# Altium schematic sheet TE0887.SchDoc(TE0887)
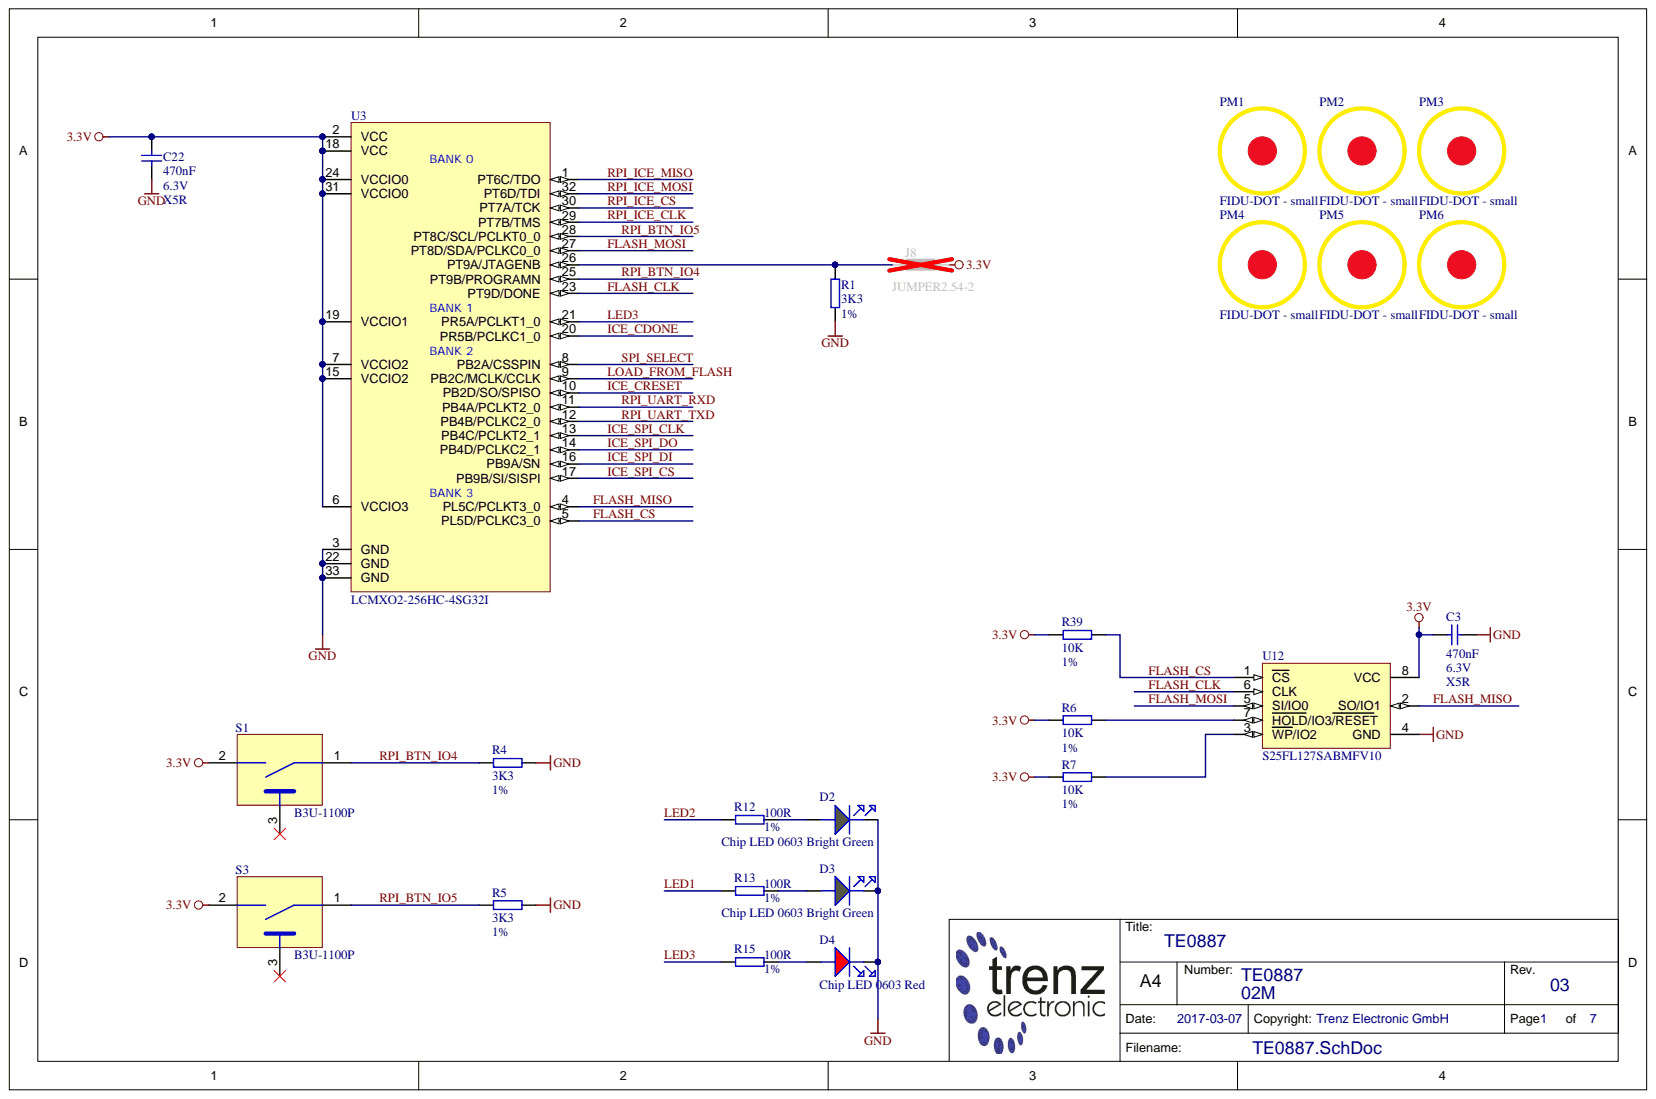
[diagram p0: TE0887.SchDoc]

## Parts
C3  470nF  Voltage: 6.3V  [CAPC0603X33N]
C22  470nF  Technology: X5R  Voltage: 6.3V  [CAPC0603X33N]
D2  Chip LED 0603 Bright Green  Case: 0603  [chipLED_0603_Green]
D3  Chip LED 0603 Bright Green  Case: 0603  [chipLED_0603_Green]
D4  Chip LED 0603 Red  Case: 0603  Database Table Name: LED  [chipLED_0603_Red]
J8  JUMPER2.54-2  [JUMPER2_54-2]
PM1  FIDU-DOT - small  [FIDU-DOT - small]
PM2  FIDU-DOT - small  [FIDU-DOT - small]
PM3  FIDU-DOT - small  [FIDU-DOT - small]
PM4  FIDU-DOT - small  [FIDU-DOT - small]
PM5  FIDU-DOT - small  [FIDU-DOT - small]
PM6  FIDU-DOT - small  [FIDU-DOT - small]
R1  3K3  Tolerance: 1%  [RESC1005X40N]
R4  3K3  Tolerance: 1%  [RESC1005X40N]
R5  3K3  Tolerance: 1%  [RESC1005X40N]
R6  10K  [RESC1005X40N]
R7  10K  Tolerance: 1%  [RESC1005X40N]
R12  100R  Tolerance: 1%  [RESC1005X40N]
R13  100R  Tolerance: 1%  [RESC1005X40N]
R15  100R  Tolerance: 1%  [RESC1005X40N]
R39  10K  Tolerance: 1%  [RESC1005X40N]
S1  B3U-1100P  [B3U-1100P]
S3  B3U-1100P  [B3U-1100P]
U3  LCMXO2-256HC-4SG32I  [PQFN50P500X500X60-33N]
U12  S25FL127SABMFV10  [SOIC127P790X216-8N]

## Nets
3.3V: C3.1 C22.1 J8.2 R6.1 R7.1 R39.1 S1.2 S3.2 U3.2(VCC) U3.6(VCCIO3) U3.7(VCCIO2) U3.15(VCCIO2) U3.18(VCC) U3.19(VCCIO1) U3.24(VCCIO0) U3.31(VCCIO0) U12.8(VCC)
GND: C3.2 C22.2 D2.K D3.K D4.K R1.1 R4.1 R5.1 U3.3(GND) U3.22(GND) U3.33(GND) U12.4(GND)
FLASH_CLK: U3.23(PT9D/DONE) U12.6(CLK)
FLASH_CS: R39.2 U3.5(PL5D/PCLKC3_0) U12.1(CS)
FLASH_MISO: U3.4(PL5C/PCLKT3_0) U12.2(SO/IO1)
FLASH_MOSI: U3.27(PT8D/SDA/PCLKC0_0) U12.5(SI/IO0)
ICE_CDONE: U3.20(PR5B/PCLKC1_0)
ICE_CRESET: U3.10(PB2D/SO/SPISO)
ICE_SPI_CLK: U3.13(PB4C/PCLKT2_1)
ICE_SPI_CS: U3.17(PB9B/SI/SISPI)
ICE_SPI_DI: U3.16(PB9A/SN)
ICE_SPI_DO: U3.14(PB4D/PCLKC2_1)
LED1: R13.1
LED2: R12.1
LED3: R15.1 U3.21(PR5A/PCLKT1_0)
LOAD_FROM_FLASH: U3.9(PB2C/MCLK/CCLK)
RPI_BTN_IO4: R4.2 S1.1 U3.25(PT9B/PROGRAMN)
RPI_BTN_IO5: R5.2 S3.1 U3.28(PT8C/SCL/PCLKT0_0)
RPI_ICE_CLK: U3.29(PT7B/TMS)
RPI_ICE_CS: U3.30(PT7A/TCK)
RPI_ICE_MISO: U3.1(PT6C/TDO)
RPI_ICE_MOSI: U3.32(PT6D/TDI)
RPI_UART_RXD: U3.11(PB4A/PCLKT2_0)
RPI_UART_TXD: U3.12(PB4B/PCLKC2_0)
SPI_SELECT: U3.8(PB2A/CSSPIN)
NetD2_A: D2.A R12.2
NetD3_A: D3.A R13.2
NetD4_A: D4.A R15.2
NetJ8_1: J8.1 R1.2 U3.26(PT9A/JTAGENB)
NetR6_2: R6.2 U12.7(HOLD/IO3/RESET)
NetR7_2: R7.2 U12.3(WP/IO2)

Not connected: S1 3; S3 3

## Library data of the parts
C3 C22 = 24921: KON 470nF X5R 6.3V SMD 20%; Database Table Name: C_0603_0201; Mixed: SMT; Preferred: Yes; Value: 470n; AN: 24921; Case: 0603 (0201); Component Kind: Standard; Library Name: TE.DbLib; Tolerance: 20%
  C3: Technology: X5R
D2 D3 = 25986: H-ART: 150 060 VS7 500 0; Mixed: SMT; Preferred: Yes; Voltage: 2.0; AN: 25986; Component Kind: Standard; Database Table Name: LED; Description: Chip LED 0603 Bright Green; Library Name: TE.DbLib; HelpURL: B:\AD\adlib\datasheet\WL_SMCW
D4 = 25985: H-ART: 150 060 RS7 500 0; Mixed: SMT; Preferred: Yes; Voltage: 2.0; AN: 25985; Component Kind: Standard; Description: Chip LED 0603 Red; Library Name: TE.DbLib; HelpURL: B:\AD\adlib\datasheet\WL_SMCW
J8 = 22330: Stiftleiste gerade 1-reihig, 2pol. (Jumper); Database Table Name: CON_HEADER; Mixed: THT; Trenz-Stock: Yes; AN: 22330; Component Kind: Standard; Library Name: TE.DbLib
PM1-PM6 = 22905: FIDU-DOT - SMALL 1mm; Database Table Name: PCB; Mixed: FIDU; AN: 22905; Component Kind: Standard; Library Name: TE.DbLib
R1 R4 R5 = 22763: WID SMD 3K3 1% 0,063W; Database Table Name: R_1005_0402; Mixed: SMT; Preferred: Yes; Value: 3.3K; AN: 22763; Case: 1005 (0402); Component Kind: Standard; Library Name: TE.DbLib; Technology: TK100
R6 R7 R39 = 22750: WID SMD 10K 1% 0,063W; Database Table Name: R_1005_0402; Mixed: SMT; Preferred: Yes; AN: 22750; Case: 1005 (0402); Component Kind: Standard; Library Name: TE.DbLib; Technology: TK100; Value: 10K
  R6: Tolerance: 1%
R12 R13 R15 = 22507: WID SMD 100R 1% 0,063W; Database Table Name: R_1005_0402; Mixed: SMT; Preferred: Yes; Value: 100; AN: 22507; Case: 1005 (0402); Component Kind: Standard; Library Name: TE.DbLib; Technology: TK100
S1 S3 = 26337: Database Table Name: PB_SW; Mixed: SMT; AN: 26337; Component Kind: Standard; Description: B3U-1100P; H-ART: B3U-1100P; Library Name: TE.DbLib; HelpURL: B:\AD\adlib\datasheet\B3U-1100P
U3 = 26395: Database Table Name: PROG_LOGIC; Mixed: SMT; Preferred: Yes; AN: 26395; Case: QFN32; Component Kind: Standard; Description: LCMXO2-256HC-4SG32I; H-ART: LCMXO2-256HC-4SG32I; Library Name: TE.DbLib; Trenz-Stock: Yes; HelpURL: B:\AD\adlib\datasheet\MachXO2
U12 = 26078: S25FL127SABMFV10 automotive SO8; Database Table Name: A_FLASH; Mixed: SMT; Preferred: Yes; AN: 26078; Case: SO8W; Component Kind: Standard; H-ART: S25FL127SABMFV10; Library Name: TE.DbLib; HelpURL: B:\AD\adlib\datasheet\S25FL12xS
